# Altium schematic sheet VidRayCurrentMonitor.SchDoc
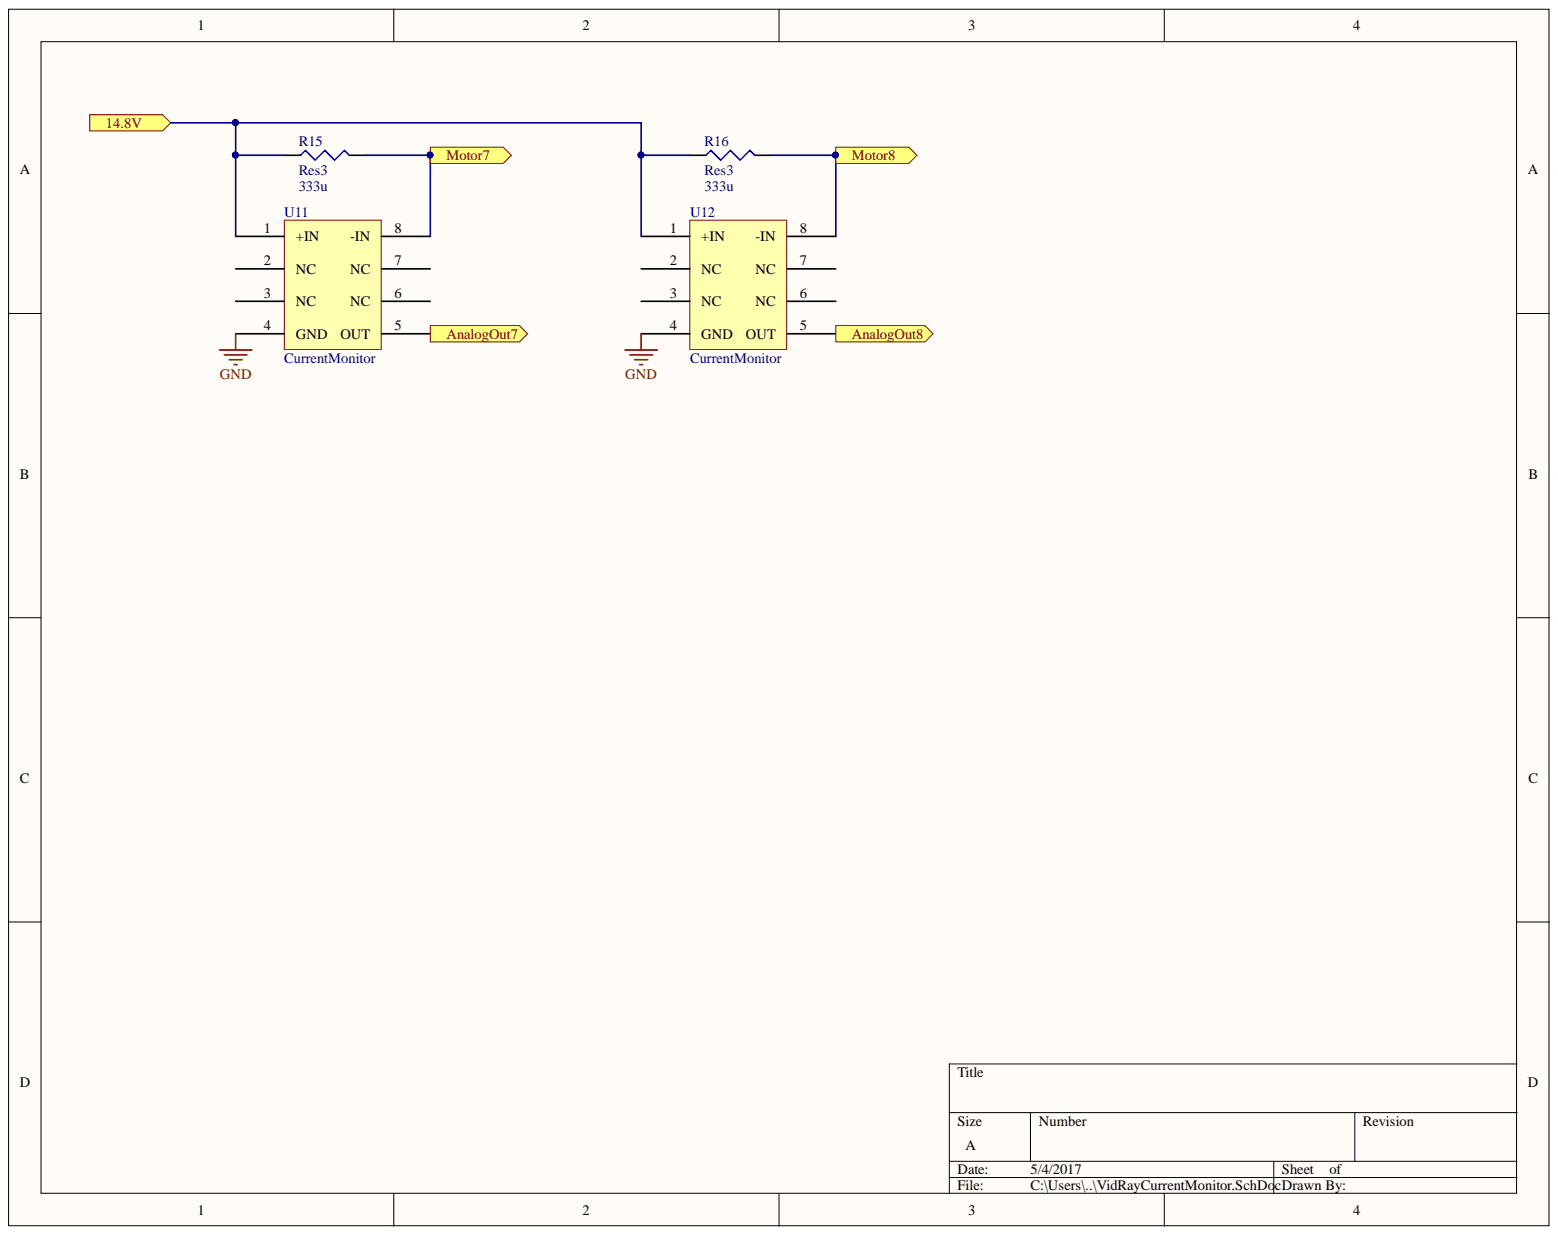
[diagram p0: VidRayCurrentMonitor.SchDoc]

## Parts
R15  Res3  value 333u  [Resistor03mOhm]
R16  Res3  value 333u  [Resistor03mOhm]
U11  CurrentMonitor  [AD8217]
U12  CurrentMonitor  [AD8217]

## Ports
14.8V, ANALOGOUT7, ANALOGOUT8, MOTOR7, MOTOR8

## Nets
GND: U11.4(GND) U12.4(GND)
14.8V: R15.1 R16.1 U11.1(+IN) U12.1(+IN) ; port 14.8V
NetR15_2: R15.2 U11.8(-IN) ; port MOTOR7
NetR16_2: R16.2 U12.8(-IN) ; port MOTOR8
NetU11_5: U11.5(OUT) ; port ANALOGOUT7
NetU12_5: U12.5(OUT) ; port ANALOGOUT8

Not connected: U11 2(NC) 3(NC) 6(NC) 7(NC); U12 2(NC) 3(NC) 6(NC) 7(NC)

## Library data of the parts
R15 R16 = Res3: Resistor; Value: 333u; Component Kind: Standard; LatestRevisionDate: 29-May-2009; LatestRevisionNote: IPC-7351 Footprint Added.; Library Name: Miscellaneous Devices.IntLib; PackageDescription: Chip Resistor; PackageReference: J1-0603; Published: 8-Jun-2000; Signal Integrity: Res; Simulation: RESISTOR; Publisher: Altium Limited
U11 U12 = AD8217: Component Kind: Standard; Library Name: AD8217.SchLib
